# Altium schematic sheet TLV521.SchDoc(TLV521)
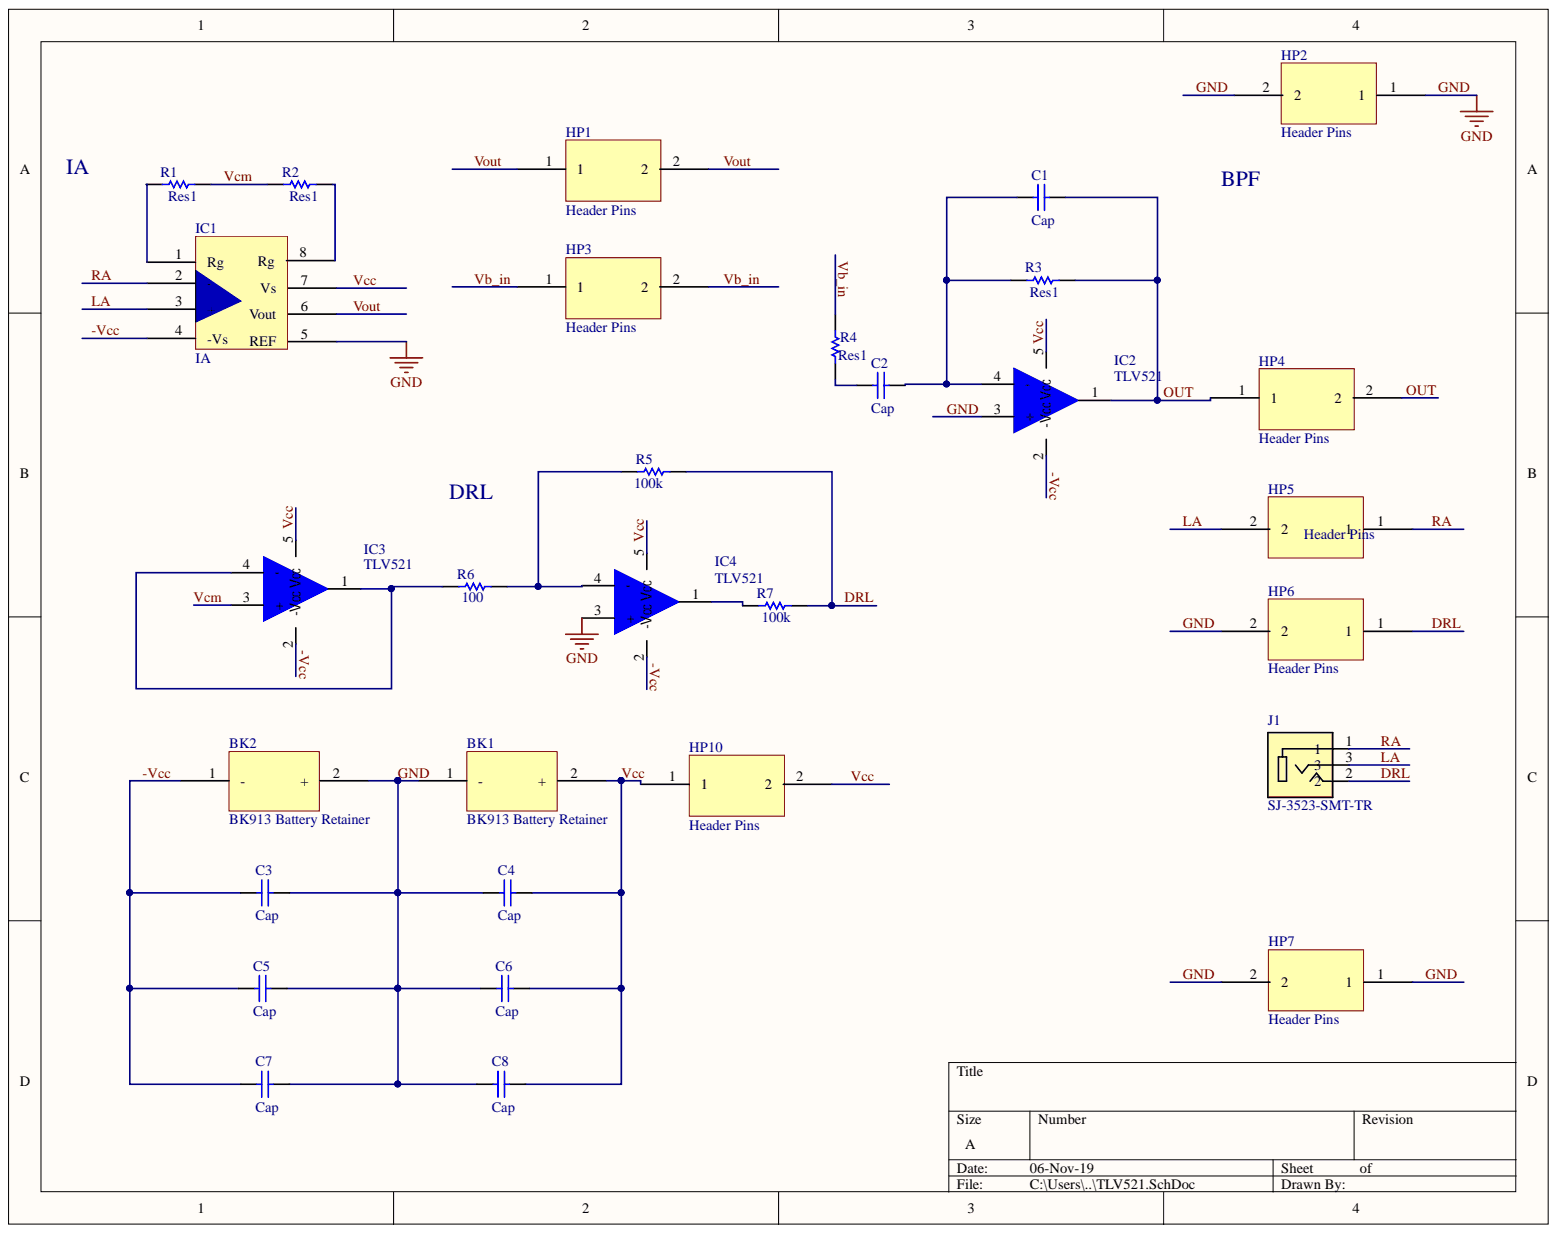
[diagram p0: TLV521.SchDoc]

## Parts
BK1  BK913 Battery Retainer  [BK913]
BK2  BK913 Battery Retainer  [BK913]
C1  Cap  [6-0805_M]
C2  Cap  [6-0805_M]
C3  Cap  [6-0805_M]
C4  Cap  [6-0805_M]
C5  Cap  [6-0805_M]
C6  Cap  [6-0805_M]
C7  Cap  [6-0805_M]
C8  Cap  [6-0805_M]
HP1  Header Pins  [Header_Pins]
HP2  Header Pins  [Header_Pins]
HP3  Header Pins  [Header_Pins]
HP4  Header Pins  [Header_Pins]
HP5  Header Pins  [Header_Pins]
HP6  Header Pins  [Header_Pins]
HP7  Header Pins  [Header_Pins]
HP10  Header Pins  [Header_Pins]
IC1  IA  [SOP2]
IC2  TLV521  [SOT65P210X110-5N]
IC3  TLV521  [SOT65P210X110-5N]
IC4  TLV521  [SOT65P210X110-5N]
J1  SJ-3523-SMT-TR  [*CUI_SJ-3523-SMT-TR]
R1  Res1  [6-0805_M]
R2  Res1  [6-0805_M]
R3  Res1  [6-0805_M]
R4  Res1  [6-0805_M]
R5  100k  [6-0805_M]
R6  100  [6-0805_M]
R7  100k  [6-0805_M]

## Nets
-Vcc: BK2.1 C3.1 C5.1 C7.1 IC1.4(-Vs) IC2.2(-Vcc) IC3.2(-Vcc) IC4.2(-Vcc)
DRL: HP6.1 J1.2 R5.2 R7.2
GND: BK1.1 BK2.2 C3.2 C4.1 C5.2 C6.1 C7.2 C8.1 HP2.1 HP2.2 HP6.2 HP7.1 HP7.2 IC1.5(REF) IC2.3(+) IC4.3(+)
LA: HP5.2 IC1.3(+) J1.3
OUT: C1.2 HP4.1 HP4.2 IC2.1 R3.2
RA: HP5.1 IC1.2(-) J1.1
Vb_in: HP3.1 HP3.2 R4.1
Vcc: BK1.2 C4.2 C6.2 C8.2 HP10.1 HP10.2 IC1.7(Vs) IC2.5(Vcc) IC3.5(Vcc) IC4.5(Vcc)
Vcm: IC3.3(+) R1.2 R2.1
Vout: HP1.1 HP1.2 IC1.6(Vout)
NetC1_1: C1.1 C2.2 IC2.4(-) R3.1
NetC2_1: C2.1 R4.2
NetIC1_1: IC1.1(Rg) R1.1
NetIC1_8: IC1.8(Rg) R2.2
NetIC3_1: IC3.1 IC3.4(-) R6.1
NetIC4_1: IC4.1 R7.1
NetIC4_4: IC4.4(-) R5.1 R6.2

## Library data of the parts
BK1 BK2 = BK-913: BATTERY RETAINER COIN PC PIN; Manufacturer 1: Memory Protection Devices; Number of Cells: 1; Termination: PC Pin; Supplier Part Number 1: BK-913-ND; Supplier 1: Digi-Key; Stock 1: 59379; RoHS: Yes; Pricing 1: 1=0.42000, 10=0.35700, 100=0.29540, 500=0.25576, 1000=0.23935 (USD); Package Quantity: 100; Mount: Through Hole,PCB; Manufacturer Part Number 1: BK-913; Library Name: TLV521.SchLib; ComponentLink1URL: https://datasheet.ciiva.com/3/bk-913-datasheet-3800.pdf?src-supplier=Digi-Key; ComponentLink1Description: https://datasheet.ciiva.com/3/bk-913-datasheet-3800.pdf?src-supplier=Digi-Key; Component Kind: Standard; Category: Battery Products
C1-C8 = Cap: Capacitor; Value: 100pF; Simulation: CAP; Signal Integrity: Cap; Published: 8-Jun-2000; PackageReference: RAD-0.3; PackageDescription: Radial Cap, Thru-Hole; 2 Leads; 0.3 in Pin Spacing; Library Name: Miscellaneous Devices.IntLib; LatestRevisionNote: Re-released for DXP Platform.; LatestRevisionDate: 17-Jul-2002; Component Kind: Standard; Publisher: Altium Limited
IC1 = AD620ARZ-REEL7: IC OPAMP INSTR 1MHZ 8SOIC; Manufacturer 1: Analog Devices; Slew Rate: 1.2V/µs; Power Supply Rejection Ratio (PSRR): 140dB; Power Dissipation: 650mW; Output Current per Channel: 18mA; Operating Supply Voltage: 18V; Operating Supply Current: 1.3mA; Number of Elements: 1; Nominal Supply Current: 900uA; Min Supply Voltage: 4.6V; Min Dual Supply Voltage: 2.3V; Input Resistance: 10GR; Input Offset Voltage (Vos): 30mV; Input Bias Current: 500pA; Dual Supply Voltage: 15V; Common Mode Rejection Ratio: 93dB; Bandwidth: 800kHz; Supplier Part Number 1: AD620ARZ-REEL7CT-ND; Supplier 1: Digi-Key; Stock 1: 12581; RoHS: Yes; Radiation Hardening: No; Pricing 1: 1=9.81000, 10=8.85800, 25=8.44600, 100=7.33360, 250=7.00400 (USD); Packaging: Tape and Reel; Package Quantity: 1; Number of Pins: 8; Number of Circuits: 1; Number of Channels: 1; Mount: Surface Mount; Min Operating Temperature: -55°C; Max Power Dissipation: 650mW; Max Operating Temperature: 125°C; Max Dual Supply Voltage: 18V; Manufacturer Part Number 1: AD620ARZ-REEL7; Library Name: TLV521.SchLib; Lead Free: Contains Lead; Contact Plating: Tin,Matte; ComponentLink9URL: https://datasheet.ciiva.com/48709/001248702-da-01-en-ic-amp-inst-lp-ad620arz-reel7-soic-8-adi-48709622.pdf?src-supplier=Conrad; ComponentLink9Description: https://datasheet.ciiva.com/48709/001248702-da-01-en-ic-amp-inst-lp-ad620arz-reel7-soic-8-adi-48709622.pdf?src-supplier=Conrad; ComponentLink8URL: https://datasheet.ciiva.com/2975/ad620-2975599.pdf?src-supplier=Avnet; ComponentLink8Description: https://datasheet.ciiva.com/2975/ad620-2975599.pdf?src-supplier=Avnet; ComponentLink7URL: https://datasheet.ciiva.com/1794/ad620-1794714.pdf?src-supplier=Digi-Key; ComponentLink7Description: https://datasheet.ciiva.com/1794/ad620-1794714.pdf?src-supplier=Digi-Key; ComponentLink6URL: https://datasheet.ciiva.com/1794/ad620-1794713.pdf?src-supplier=Digi-Key; ComponentLink6Description: https://datasheet.ciiva.com/1794/ad620-1794713.pdf?src-supplier=Digi-Key; ComponentLink5URL: https://datasheet.ciiva.com/1794/ad620-1794712.pdf?src-supplier=Digi-Key; ComponentLink5Description: https://datasheet.ciiva.com/1794/ad620-1794712.pdf?src-supplier=Digi-Key; ComponentLink4URL: https://datasheet.ciiva.com/2976/ad620-2976391.pdf?src-supplier=Avnet; ComponentLink4Description: https://datasheet.ciiva.com/2976/ad620-2976391.pdf?src-supplier=Avnet; ComponentLink3URL: https://datasheet.ciiva.com/26847/ad620arz-reel7-26847213.pdf?src-supplier=IIIC; ComponentLink3Description: https://datasheet.ciiva.com/26847/ad620arz-reel7-26847213.pdf?src-supplier=IIIC; ComponentLink2URL: https://datasheet.ciiva.com/1579/26155-1579655.pdf?src-supplier=Newark; ComponentLink2Description: https://datasheet.ciiva.com/1579/26155-1579655.pdf?src-supplier=Newark; ComponentLink1URL: https://datasheet.ciiva.com/15128/getdatasheetpartid-88990-15128224.pdf?src-supplier=Verical; ComponentLink1Description: https://datasheet.ciiva.com/15128/getdatasheetpartid-88990-15128224.pdf?src-supplier=Verical; Component Kind: Standard; Category: Integrated Circuits (ICs); Case/Package: SOIC; -3db Bandwidth: 1MHz
IC2-IC4 = TLV521DCKT: IC OP AMP 6KHZ RR SC-70-5; Manufacturer 1: Texas Instruments; Slew Rate: 2.5V/µs; Output Current per Channel: 12mA; Operating Supply Current: 350nA; Number of Circuits: 1; Input Offset Voltage (Vos): 100uV; Input Bias Current: 1pA; Supplier Part Number 1: 296-44482-1-ND; Supplier 1: Digi-Key; Stock 1: 7572; RoHS: Yes; REACH SVHC: No SVHC; Pricing 1: 1=0.64000, 10=0.54800, 100=0.40950 (USD); Packaging: Cut Tape; Number of Pins: 5; Number of Channels: 1; Number of Amplifiers: 1; Mount: Surface Mount; Min Operating Temperature: -40°C; Max Operating Temperature: 125°C; Manufacturer Part Number 1: TLV521DCKT; Library Name: TLV521.SchLib; Lead Free: Lead Free; Gain Bandwidth Product: 6kHz; ComponentLink4URL: http://www.ti.com/general/docs/lit/getliterature.tsp?genericPartNumber=TLV521&amp;&amp;fileType=pdf&src-supplier=Farnell; ComponentLink4Description: http://www.ti.com/general/docs/lit/getliterature.tsp?genericPartNumber=TLV521&amp;&amp;fileType=pdf&src-supplier=Farnell; ComponentLink3URL: http://www.ti.com/lit/ds/symlink/tlv521.pdf?src-supplier=Digi-Key; ComponentLink3Description: http://www.ti.com/lit/ds/symlink/tlv521.pdf?src-supplier=Digi-Key; ComponentLink2URL: http://www.ti.com/lit/pdf/slyt701?src-supplier=Digi-Key; ComponentLink2Description: http://www.ti.com/lit/pdf/slyt701?src-supplier=Digi-Key; ComponentLink1URL: http://www.ti.com/general/docs/lit/getliterature.tsp?genericPartNumber=TLV521&&fileType=pdf&src-supplier=Element14; ComponentLink1Description: http://www.ti.com/general/docs/lit/getliterature.tsp?genericPartNumber=TLV521&&fileType=pdf&src-supplier=Element14; Component Kind: Standard; Category: Integrated Circuits (ICs); Case/Package: SC; Bandwidth: 6kHz
J1 = SJ-3523-SMT-TR: 3.5 mm, Stereo, Right Angle, Surface Mount _SMT_, Audio Jack Connector; MF: CUI Devices; SnapEDA_Link: https://www.snapeda.com/parts/SJ-3523-SMT-TR/CUI%20Devices/view-part/?ref=snap; Package: LGA-10 STMicroelectronics; MP: SJ-3523-SMT-TR; Library Name: TLV521.SchLib; Digi-Key_Purchase_URL: https://www.digikey.com/product-detail/en/cui-devices/SJ-3523-SMT-TR/CP-3523SJCT-ND/669704?utm_source=snapeda&utm_medium=aggregator&utm_campaign=symbol; Digi-Key_Part_Number: CP-3523SJCT-ND; CUI_purchase_URL: https://www.cuidevices.com/product/interconnect/connectors/audio-connectors/jacks/sj-352x-smt-series?utm_source=snapeda.com&utm_medium=referral&utm_campaign=snapedaBOM; Component Kind: Standard
R1-R7 = Res1: Resistor; Value: 1K; Simulation: RESISTOR; Signal Integrity: Res; Published: 8-Jun-2000; PackageReference: AXIAL-0.3; PackageDescription: Axial Device, Thru-Hole; 2 Leads; 0.3 in Pin Spacing; Library Name: Miscellaneous Devices.IntLib; LatestRevisionNote: Re-released for DXP Platform.; LatestRevisionDate: 17-Jul-2002; Component Kind: Standard; Publisher: Altium Limited
HP1-HP7 HP10 = Header Pins: Library Name: TLV521.SchLib; Component Kind: Standard
